# Altium schematic sheet Connections.SchDoc
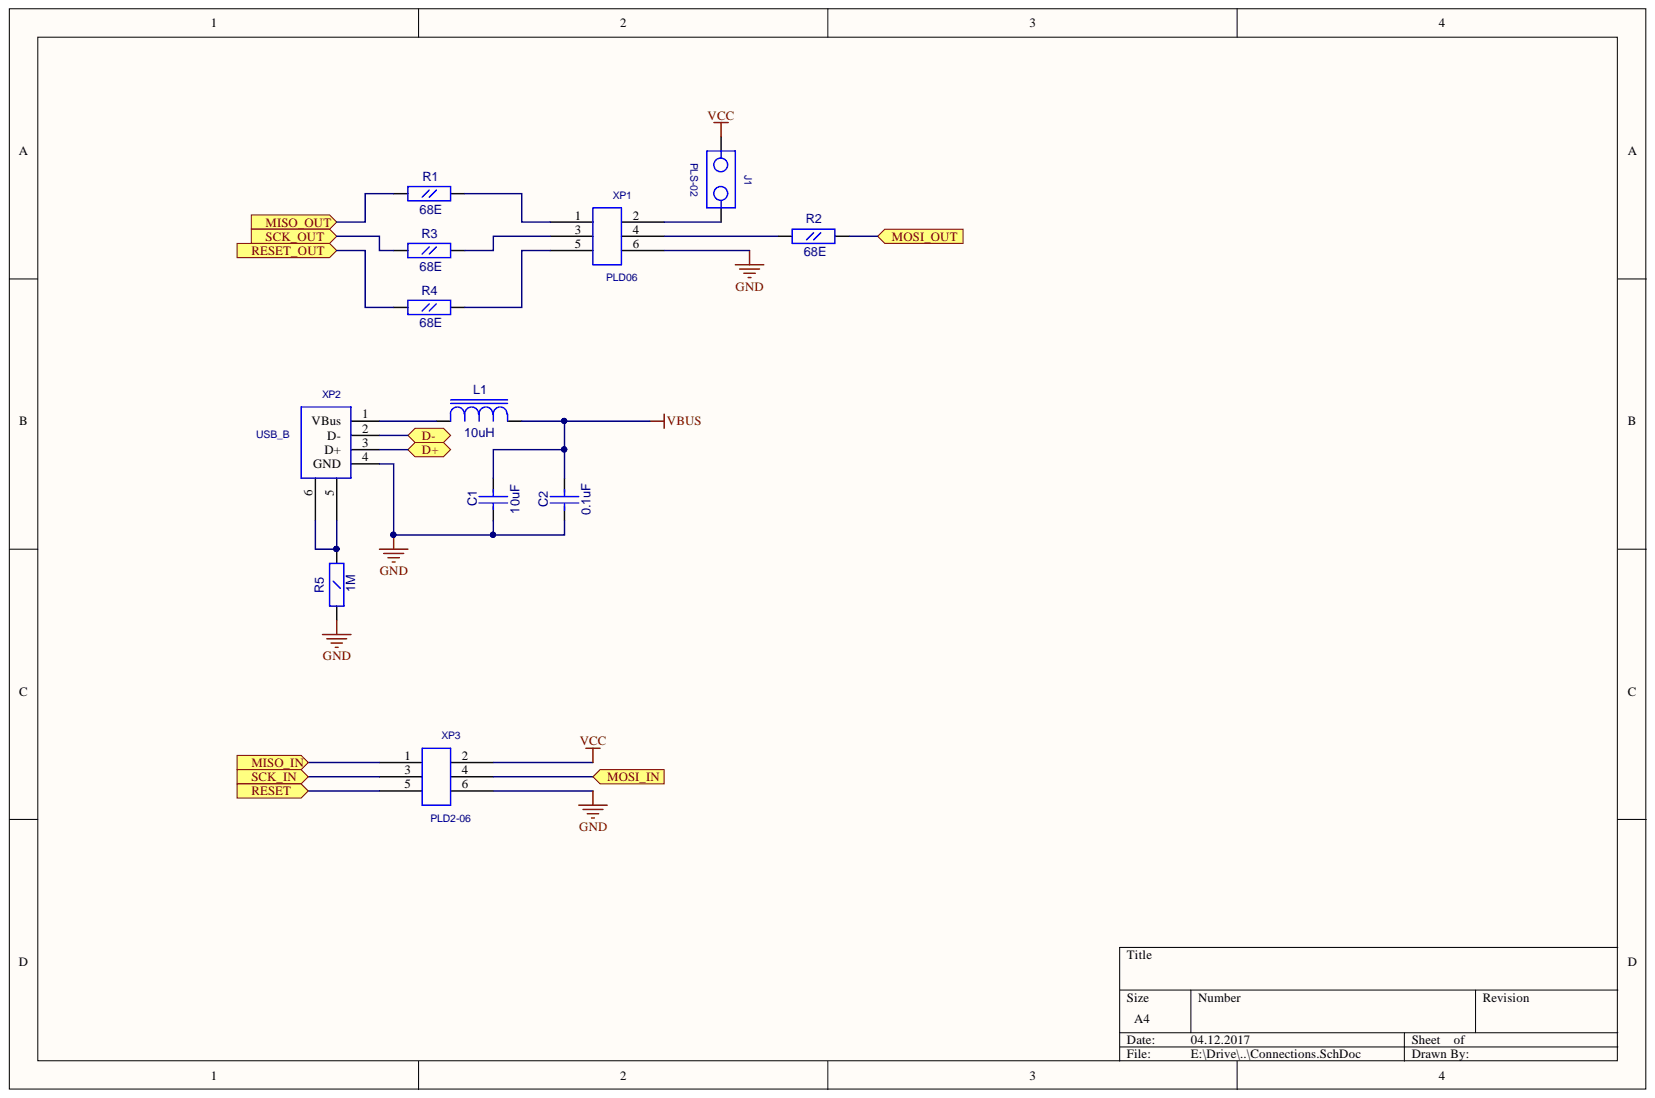
[diagram p0: Connections.SchDoc]

## Parts
C1  10uF  [CC0805]
C2  0.1uF  [CC0603]
J1  PLS-02  [PLS-02]
L1  value 10uH  [RC0805]  (hidden comment: L0805)
R1  68E  [RC0603]
R2  68E  [RC0603]
R3  68E  [RC0603]
R4  68E  [RC0603]
R5  1M  [RC1206]
XP1  PLD06  [PIN6]
XP2  USB_B  [USB-B]
XP3  PLD2-06  [PLD2-06]

## Ports
D+, D-, MISO_IN, MISO_OUT, MOSI_IN, MOSI_OUT, RESET, RESET_OUT, SCK_IN, SCK_OUT

## Nets
GND: C1.1 C2.1 R5.1 XP1.6 XP2.4(GND) XP3.6
VBUS: C1.2 C2.2 L1.2
VCC: J1.1 XP3.2
MISO_IN: XP3.1 ; port MISO_IN
MISO_OUT: R1.1 ; port MISO_OUT
MOSI_IN: XP3.4 ; port MOSI_IN
MOSI_OUT: R2.2 ; port MOSI_OUT
RESET: XP3.5 ; port RESET
RESET_OUT: R4.1 ; port RESET_OUT
SCK_IN: XP3.3 ; port SCK_IN
SCK_OUT: R3.1 ; port SCK_OUT
NetJ1_2: J1.2 XP1.2
NetL1_1: L1.1 XP2.1(VBus)
NetR1_2: R1.2 XP1.1
NetR2_1: R2.1 XP1.4
NetR3_2: R3.2 XP1.3
NetR4_2: R4.2 XP1.5
NetR5_2: R5.2 XP2.5 XP2.6
NetXP2_2: XP2.2(D-)
NetXP2_3: XP2.3(D+)

## Library data of the parts
C1 = CC0805: Component Kind: Standard; Description: CC0805; Value: 10uF; Library Name: gprs_modem_2.SchLib
C2 = CC0603: Component Kind: Standard; Description: CC0603; Value: 0.1uF; Library Name: gprs_modem_2.SchLib
R1-R4 = RC0603: Component Kind: Standard; Description: RC0603; Value: 68E; Library Name: gprs_modem_2.SchLib
R5 = RC1206: Component Kind: Standard; Description: RC1206; Value: 1M; Library Name: gprs_modem_2.SchLib
J1 = PLS-02: Component Kind: Standard; Description: PLS-02; Library Name: gprs_modem_2.SchLib
L1 = L0805: Component Kind: Standard; Description: L0805; Library Name: gprs_modem_2.SchLib
XP1 = PLD06: Component Kind: Standard; Description: PLD06; Library Name: gprs_modem_2.SchLib
XP2 = USB_B: Component Kind: Standard; Description: USB_B; Library Name: gprs_modem_2.SchLib
XP3 = PLD2-06: Component Kind: Standard; Description: PLD2-06; Library Name: gprs_modem_2.SchLib
